# Altium schematic sheet AT25EU - 16 MBit SPI.SchDoc
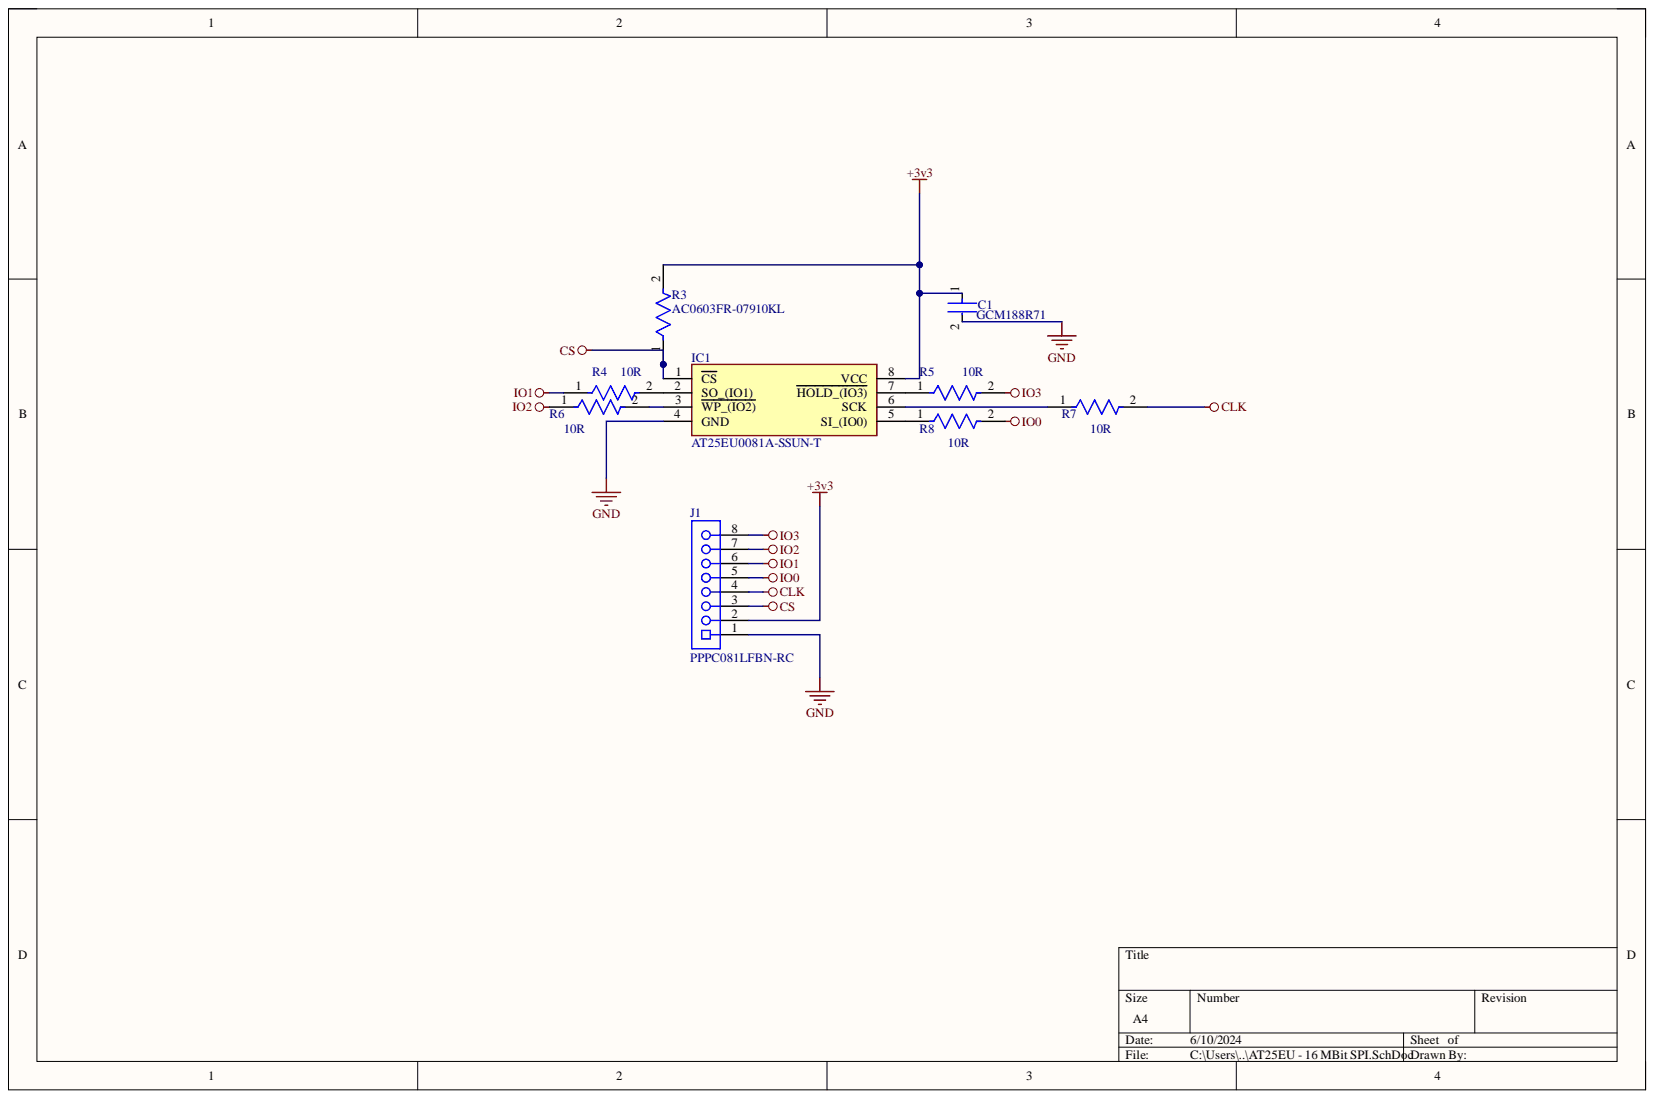
[diagram p0: AT25EU - 16 MBit SPI.SchDoc]

## Parts
C1  GCM188R71  [FP-GCM188-0_1-IPC_B]
IC1  AT25EU0081A-SSUN-T  [SOIC127P600X175-8N]
J1  PPPC081LFBN-RC  [SLIN-PPPC081LFBN-RC_V]
R3  AC0603FR-07910KL  [FP-AC0603-IPC_B]
R4  10R  [FP-AC0603-MFG]
R5  10R  [FP-AC0603-MFG]
R6  10R  [FP-AC0603-MFG]
R7  10R  [FP-AC0603-MFG]
R8  10R  [FP-AC0603-MFG]

## Nets
+3v3: C1.1 IC1.8(VCC) J1.2 R3.2
CLK: J1.4 R7.2
CS: IC1.1(CS) J1.3 R3.1
GND: C1.2 IC1.4(GND) J1.1
IO0: J1.5 R8.2
IO1: J1.6 R4.1
IO2: J1.7 R6.1
IO3: J1.8 R5.2
NetIC1_2: IC1.2(SO_(IO1)) R4.2
NetIC1_3: IC1.3(WP_(IO2)) R6.2
NetIC1_5: IC1.5(SI_(IO0)) R8.1
NetIC1_6: IC1.6(SCK) R7.1
NetIC1_7: IC1.7(HOLD_(IO3)) R5.1

## Library data of the parts
C1 = CMP-2006-02357-2: Ceramic Capacitor for Automotive 1uF  ±10% 16VDC X7R 0603 Paper T/R; Voltage: 16V; Capacitance: 1uF; Case Code (Imperial): 0603; Case Code (Metric): 1608; Case/Package: 0603; Component Kind: Standard; Depth: 0.8mm; Dielectric: X7R; Height: 0.8mm; Lead Free: Lead Free; Length: 1.6mm; Library Name: Altium Content Vault; Max Operating Temperature: 125°C; Min Operating Temperature: -55°C; Mount: Surface Mount; Package Quantity: 1; Packaging: Tape and Reel; Radiation Hardening: No; Ratings: AEC-Q200; REACH SVHC: No SVHC; Termination: SMD/SMT; Thickness: 0.035inch; Tolerance: 10%; Voltage Rating: 16V; Voltage Rating (DC): 16V; Width: 0.031inch
IC1 = AT25EU0081A-SSUN-T: Integrated Circuit; Manufacturer_Name: Renesas Electronics; Component Kind: Standard; Datasheet Link: https://www.renesas.com/us/en/document/dst/at25eu0081a-datasheet; Height: 1.75mm; Library Name: SamacSys.SchLib; Manufacturer_Part_Number: AT25EU0081A-SSUN-T
J1 = CMP-002-00028-2: Female Header, Pitch 2.54 mm, 1 x 8 Position, Height 8.5 mm, Tail Length 3.2 mm, -40 to 105 degC, RoHS, Bulk; Manufacturer: Sullins; Case/Package: PPPC081LFBN-RC; Component Kind: Standard; Connector Type: Header; Datasheet URL: http://sullinscorp.com/catalogs/101_PAGE114-115_.100_FEMALE_HDR.pdf; Datasheet Version: Jan-15; Gender: Female; Max Operating Temperature: 105°C; Min Operating Temperature: -40°C; Mounting Technology: TH; Package Description: Female Header, Pitch 2.54 mm, 1 x 8 Position, Height 8.5 mm, Tail Length 3.2 mm; Package Reference: PPPC081LFBN-RC; RoHS Compliant: Unknown; Library Name: CubeSat Team
R3 = CMP-03412-028406-2: RES 910KΩ 1.00% 0.1W 0603; Max Power Dissipation: 100mW; Resistance: 910kR; Temperature Coefficient: 100ppm/°C; Tolerance: 1%; Voltage Rating: 50V; Case Code (Imperial): 0603; Case Code (Metric): 1608; Case/Package: 0603; Component Kind: Standard; Features: Moisture Resistant; Height: 0.022inch; Length: 0.063inch; Library Name: Altium Content Vault; Max Operating Temperature: 155°C; Min Operating Temperature: -55°C; Number of Terminations: 2; Packaging: Tape and Reel; Power Rating: 100mW; Resistor Type: Thick Film; RoHS Compliant: Yes; Series: AC; Termination: SMD/SMT; Width: 0.8mm
R4-R8 = CMP-2000-04819-3: RES 10Ω 1.00% 0.1W 0603; Max Power Dissipation: 100mW; Temperature Coefficient: 200ppm/°C; Tolerance: 1%; Voltage Rating: 50V; Working Voltage: 75V; Case Code (Imperial): 0603; Case Code (Metric): 1608; Case/Package: 0603; Component Kind: Standard; Features: Moisture Resistant; Height: 0.022inch; Lead Free: Lead Free; Length: 0.063inch; Library Name: Altium Content Vault; Max Operating Temperature: 155°C; Min Operating Temperature: -55°C; Moisture Sensitivity Level: 1; Number of Terminations: 2; Package Quantity: 1; Packaging: Tape and Reel; Power Rating: 100mW; Resistance: 10R; Resistor Type: Thick Film; RoHS Compliant: Yes; Series: AC; Technology: THICK FILM; Termination: SMD/SMT; Width: 0.031inch
